# Altium schematic sheet Power_Supply_9V.SchDoc
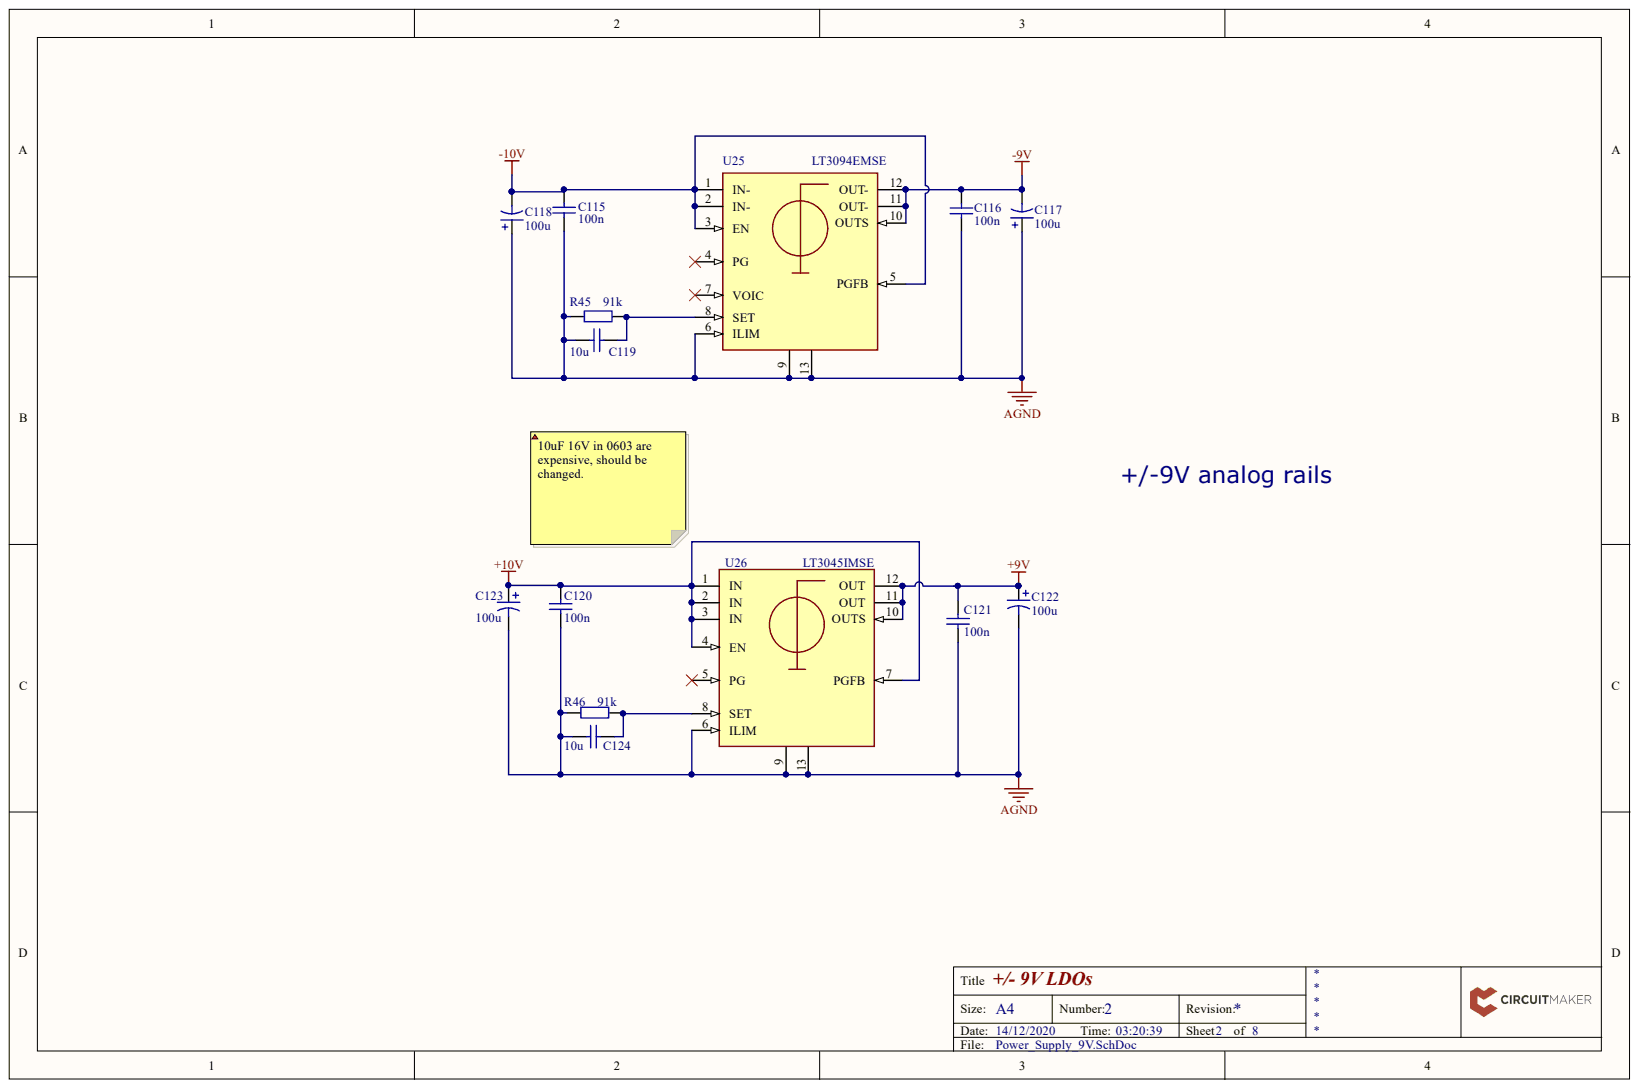
[diagram p0: Power_Supply_9V.SchDoc]

## Parts
C115  100n  [PCB-rf0pzaa82dpbeu9l4sxm-1]
C116  100n  [PCB-rf0pzaa82dpbeu9l4sxm-1]
C117  100u  [PCB-9bfrndi9huxo1n7cihpl-1]
C118  100u  [PCB-9bfrndi9huxo1n7cihpl-1]
C119  10u  [PCB-rf0pzaa82dpbeu9l4sxm-1]
C120  100n  [PCB-rf0pzaa82dpbeu9l4sxm-1]
C121  100n  [PCB-rf0pzaa82dpbeu9l4sxm-1]
C122  100u  [PCB-9bfrndi9huxo1n7cihpl-1]
C123  100u  [PCB-9bfrndi9huxo1n7cihpl-1]
C124  10u  [PCB-rf0pzaa82dpbeu9l4sxm-1]
R45  91k  [PCB-3d5y3t7f8drf35yjcj59-1]
R46  91k  [PCB-3d5y3t7f8drf35yjcj59-1]
U25  LT3094EMSE  [PCB-wizual0g3wynqnqrtsvy-1]
U26  LT3045IMSE  [PCB-wov5llpgebpq1pwypz48-1]

## Nets
+9V: C121.2 C122.1 U26.10(OUTS) U26.11(OUT) U26.12(OUT)
+10V: C120.2 C123.1 U26.1(IN) U26.2(IN) U26.3(IN) U26.4(EN) U26.7(PGFB)
-9V: C116.2 C117.2 U25.10(OUTS) U25.11(OUT-) U25.12(OUT-)
-10V: C115.2 C118.2 U25.1(IN-) U25.2(IN-) U25.3(EN) U25.5(PGFB)
AGND: C115.1 C116.1 C117.1 C118.1 C119.2 C120.1 C121.1 C122.2 C123.2 C124.2 R45.2 R46.2 U25.6(ILIM) U25.9 U25.13 U26.6(ILIM) U26.9 U26.13
NetC119_1: C119.1 R45.1 U25.8(SET)
NetC124_1: C124.1 R46.1 U26.8(SET)

Not connected: U25 4(PG) 7(VOIC); U26 5(PG)

## Library data of the parts
C115 C116 C119-C121 C124 = CMP-x8hddryxw4o380xfi98v-1: Capacitor 0603; Component Kind: Standard; CustomComponentID: x8hddryxw4o380xfi98v; LCSC Part #: C19702; Library Name: FavoritesLibrary
  C115 C116 C120 C121: LCSC Part #: C109474; LCSC Part #: C14663
  C119 C124: LCSC Part #: C1546; LCSC Part #: C81598; LCSC Part #: C21190; LCSC Part #: C25804
C117 C118 C122 C123 = CMP-6546a831a81eba81-4: In a Pack of 25, KEMET 100?F Electrolytic Capacitor 10V dc Through Hole - A758EK107M1AAAE016; Capacitance: 100 µF; Capacitance Tolerance: ±20%; Equivalent Series Resistance (ESR): 16.0 m?; Voltage Rating (DC): 10.0 V; Component Kind: Standard; Library Name: FavoritesLibrary; Manufacturer Part Number: A758EK107M1AAAE016; Mounting Style: Through Hole; OctopartID: 6546a831a81eba81; Octopart URL: https://octopart.com/a758ek107m1aaae016-kemet-75360318; Operating Temperature: 105 °C (max); RoHS: Compliant; Size-Diameter: 6.30 mm; Size-Height: 8.00 mm
R45 R46 = CMP-xcu3s4ikiffkkgbeyow5-1: Resistor 0603; Component Kind: Standard; CustomComponentID: xcu3s4ikiffkkgbeyow5; LCSC Part #: C23345; LCSC Part #: C23265; Library Name: FavoritesLibrary
U25 = CMP-cc5900e31f81139e-11: LDO Regulator Neg 0V to -19.5V 0.5A 12-Pin MSOP EP Tube; Manufacturer Part Number: LT3094EMSE#PBF; Lead-Free Status: Contains Lead; Component Kind: Standard; Library Name: FavoritesLibrary; Lifecycle Status: Active; Number of Pins: 12; OctopartID: a88b642e33ab774c; Octopart URL: https://octopart.com/lt3094emse%23pbf-analog+devices-95403590; RoHS: Compliant
U26 = CMP-53899e0384ae8c4e-10: LDO Regulator Pos 0V to 20V 0.5A Automotive 12-Pin MSOP EP Tube; Manufacturer Part Number: LT3045IMSE#PBF; Lead-Free Status: Contains Lead; Output Current: 500 mA; Case/Package: MSOP; Component Kind: Standard; Library Name: FavoritesLibrary; Lifecycle Status: Active; Number of Pins: 12; OctopartID: 53899e0384ae8c4e; Octopart URL: https://octopart.com/lt3045imse%23pbf-analog+devices+%2F+linear+technology-75559489; Operating Temperature: -40.0 °C (min); RoHS: Compliant
